# Altium schematic sheet RGB_LED.SchDoc
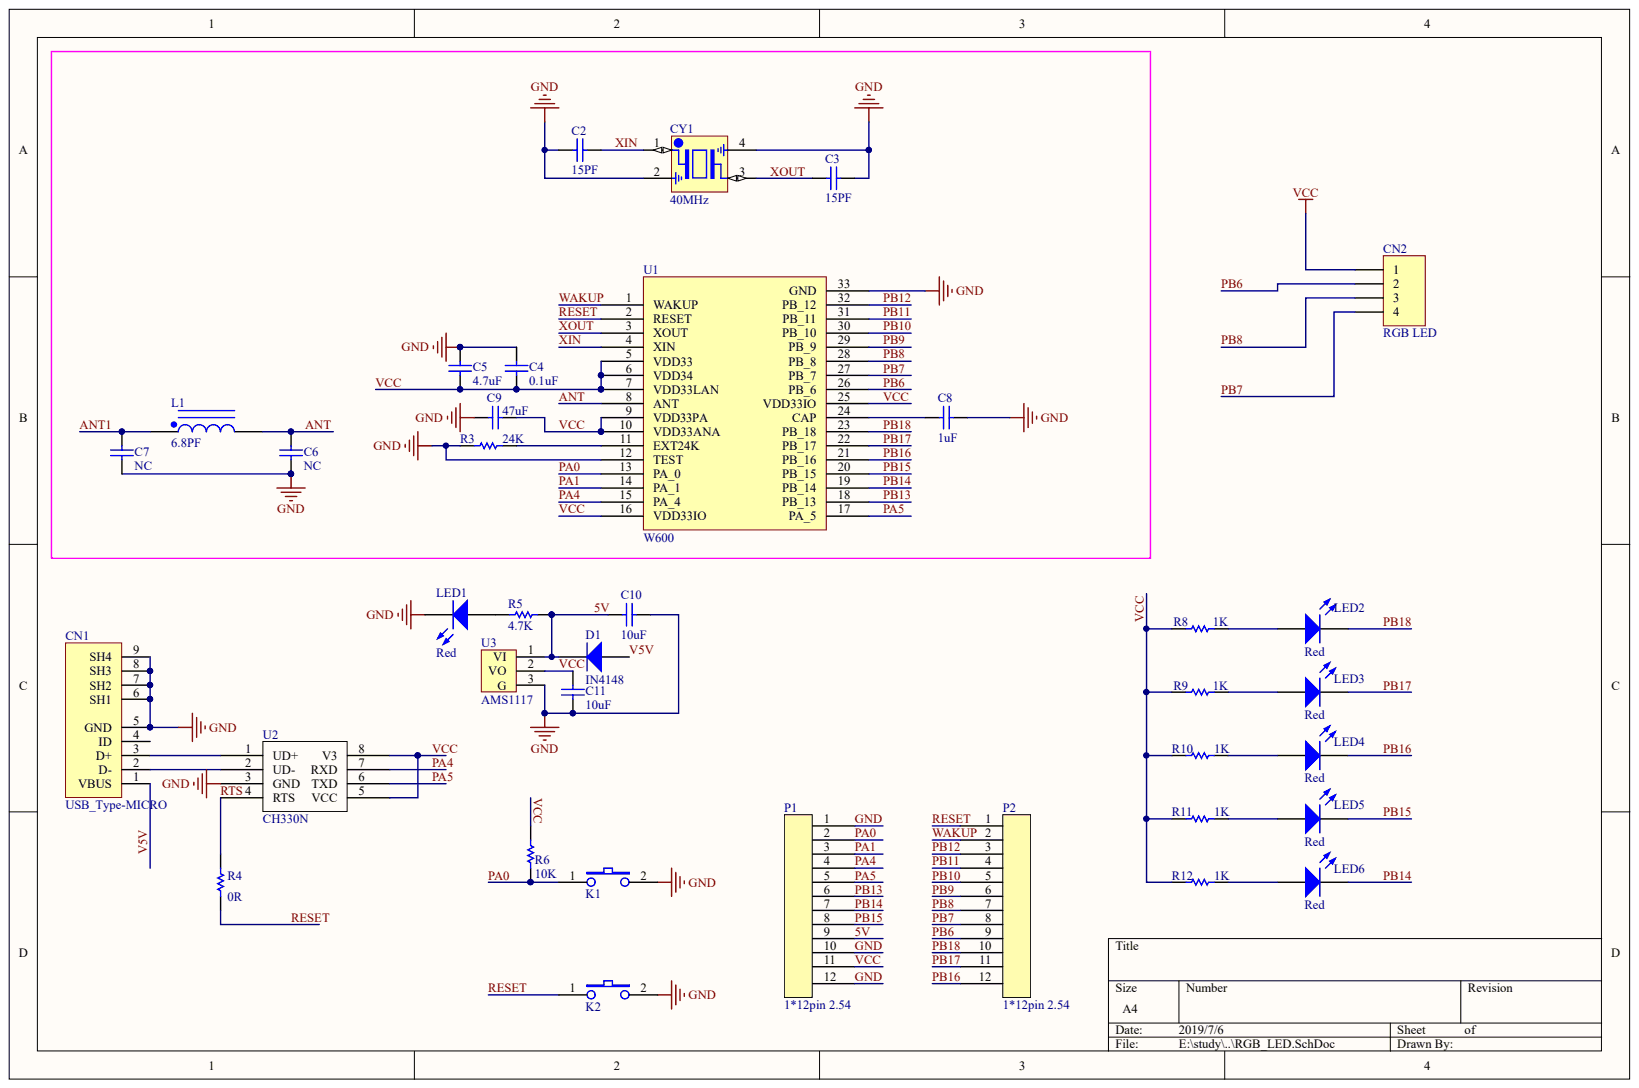
[diagram p0: RGB_LED.SchDoc]

## Parts
C2  15PF
C3  15PF
C4  0.1uF
C5  4.7uF
C6  NC
C7  NC
C8  1uF
C9  47uF
C10  10uF
C11  10uF
CN1  USB_Type-MICRO
CN2  RGB LED
CY1  40MHz
D1  IN4148
K1  Button  (value not drawn)
K2  Button  (value not drawn)
L1  6.8PF
LED1  Red
LED2  Red
LED3  Red
LED4  Red
LED5  Red
LED6  Red
P1  1*12pin 2.54
P2  1*12pin 2.54
R3  24K
R4  0R
R5  4.7K
R6  10K
R8  1K
R9  1K
R10  1K
R11  1K
R12  1K
U1  W600
U2  CH330N
U3  AMS1117

## Nets
5V: C10.2 D1.2 P1.9 R5.2 U3.1
ANT: C6.2 L1.2 U1.8(ANT)
ANT1: C7.2 L1.1
GND: C2.1 C3.2 C4.2 C5.2 C6.1 C7.1 C8.2 C9.1 C10.1 C11.2 CN1.5(GND) CN1.6(SH1) CN1.7(SH2) CN1.8(SH3) CN1.9(SH4) CY1.2 CY1.4 K1.2 K2.2 LED1.2 P1.1 P1.10 P1.12 R3.1 U1.12(TEST) U1.33(GND) U2.3(GND) U3.3
NETLABEL1: CN2.2
PA0: K1.1 P1.2 R6.1 U1.13(PA_0)
PA1: P1.3 U1.14(PA_1)
PA4: P1.4 U1.15(PA_4) U2.7(RXD)
PA5: P1.5 U1.17(PA_5) U2.6(TXD)
PB6: P2.9 U1.26(PB_6)
PB7: CN2.4 P2.8 U1.27(PB_7)
PB8: CN2.3 P2.7 U1.28(PB_8)
PB9: P2.6 U1.29(PB_9)
PB10: P2.5 U1.30(PB_10)
PB11: P2.4 U1.31(PB_11)
PB12: P2.3 U1.32(PB_12)
PB13: P1.6 U1.18(PB_13)
PB14: LED6.2 P1.7 U1.19(PB_14)
PB15: LED5.2 P1.8 U1.20(PB_15)
PB16: LED4.2 P2.12 U1.21(PB_16)
PB17: LED3.2 P2.11 U1.22(PB_17)
PB18: LED2.2 P2.10 U1.23(PB_18)
RESET: K2.1 P2.1 R4.1 U1.2(RESET)
RTS: R4.2 U2.4(RTS)
V5V: CN1.1(VBUS) D1.1
VCC: C4.1 C5.1 C9.2 C11.1 CN2.1 P1.11 R6.2 R8.2 R9.2 R10.2 R11.2 R12.2 U1.5(VDD33) U1.6(VDD34) U1.7(VDD33LAN) U1.9(VDD33PA) U1.10(VDD33ANA) U1.16(VDD33IO) U1.25(VDD33IO) U2.5(VCC) U2.8(V3) U3.2
WAKUP: P2.2 U1.1(WAKUP)
XIN: C2.2 CY1.1 U1.4(XIN)
XOUT: C3.1 CY1.3 U1.3(XOUT)
NetC8_1: C8.1 U1.24(CAP)
NetCN1_2: CN1.2(D-) U2.2(UD-)
NetCN1_3: CN1.3(D+) U2.1(UD+)
NetLED1_1: LED1.1 R5.1
NetLED2_1: LED2.1 R8.1
NetLED3_1: LED3.1 R9.1
NetLED4_1: LED4.1 R10.1
NetLED5_1: LED5.1 R11.1
NetLED6_1: LED6.1 R12.1
NetR3_2: R3.2 U1.11(EXT24K)

Not connected: CN1 4(ID)

## Library data of the parts
C2-C11 CN1 CN2 CY1 D1 K1 K2 L1 LED1-LED6 P1 P2 R3-R6 R8-R12 U1-U3 = (no symbol name)
  CN2: LatestRevisionDate: 17-Jul-2002; LatestRevisionNote: Re-released for DXP Platform.; Publisher: Altium Limited
